# Altium schematic sheet lcd.SchDoc(lcd)
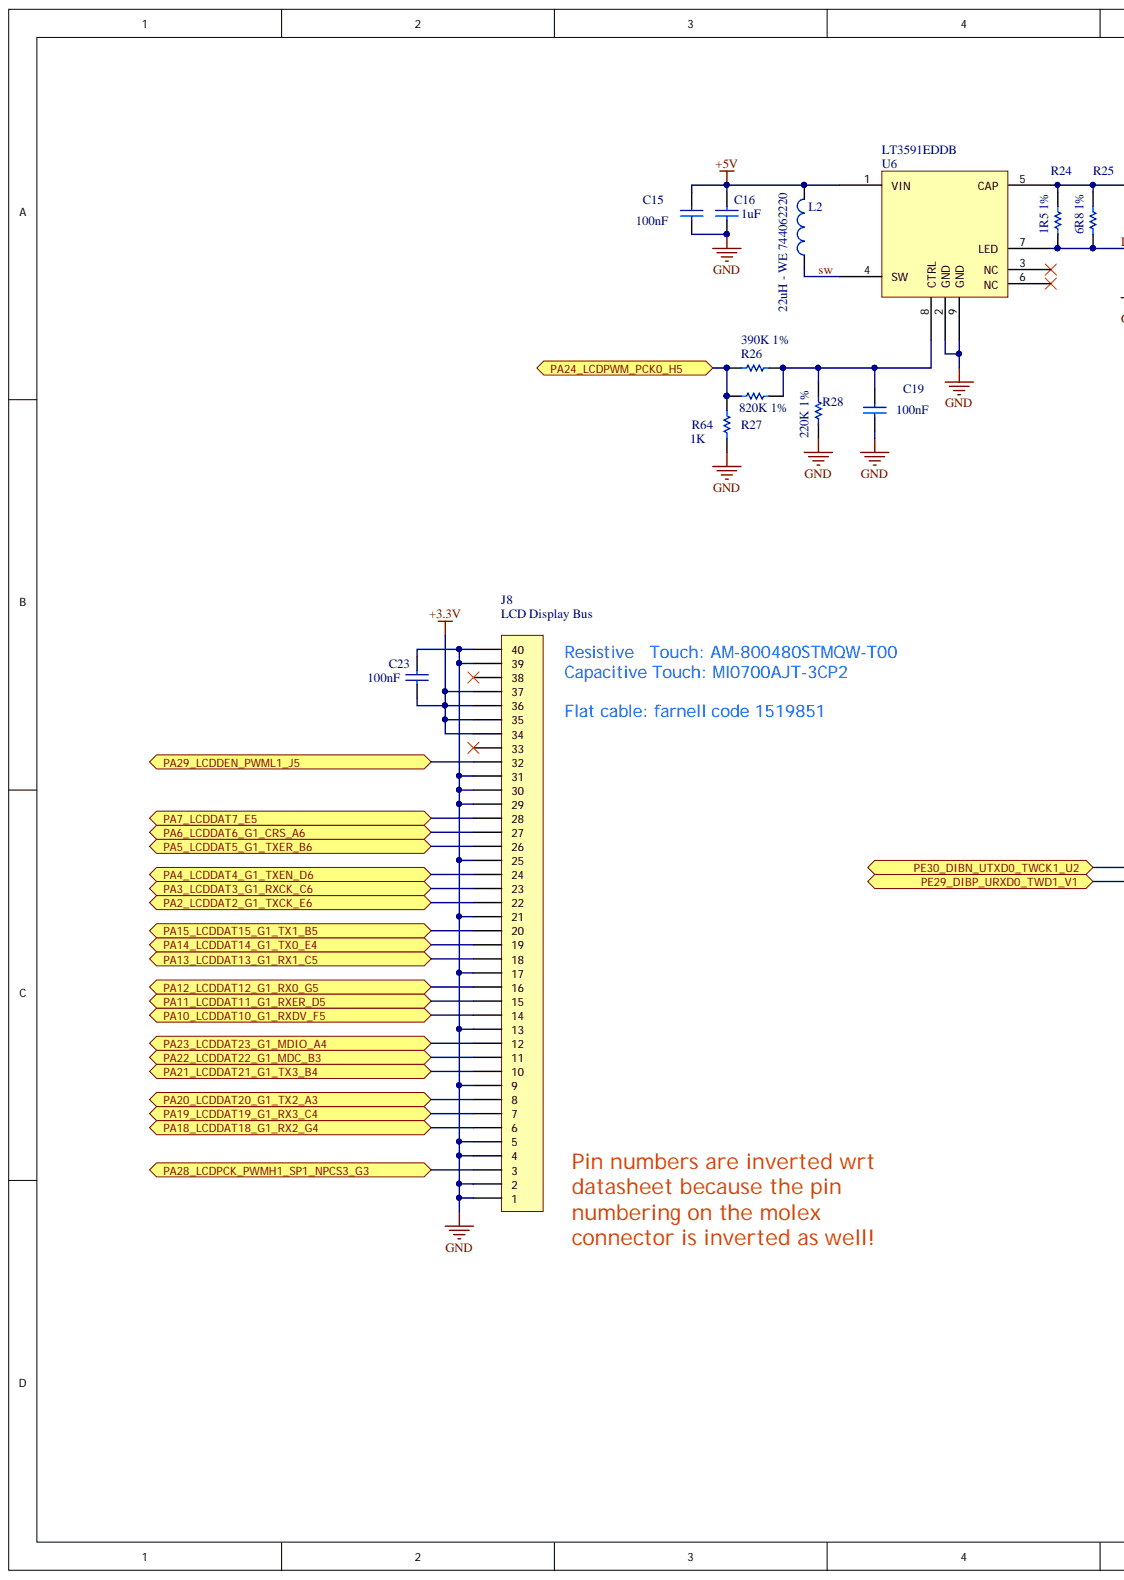
[diagram p0: lcd.SchDoc, left]
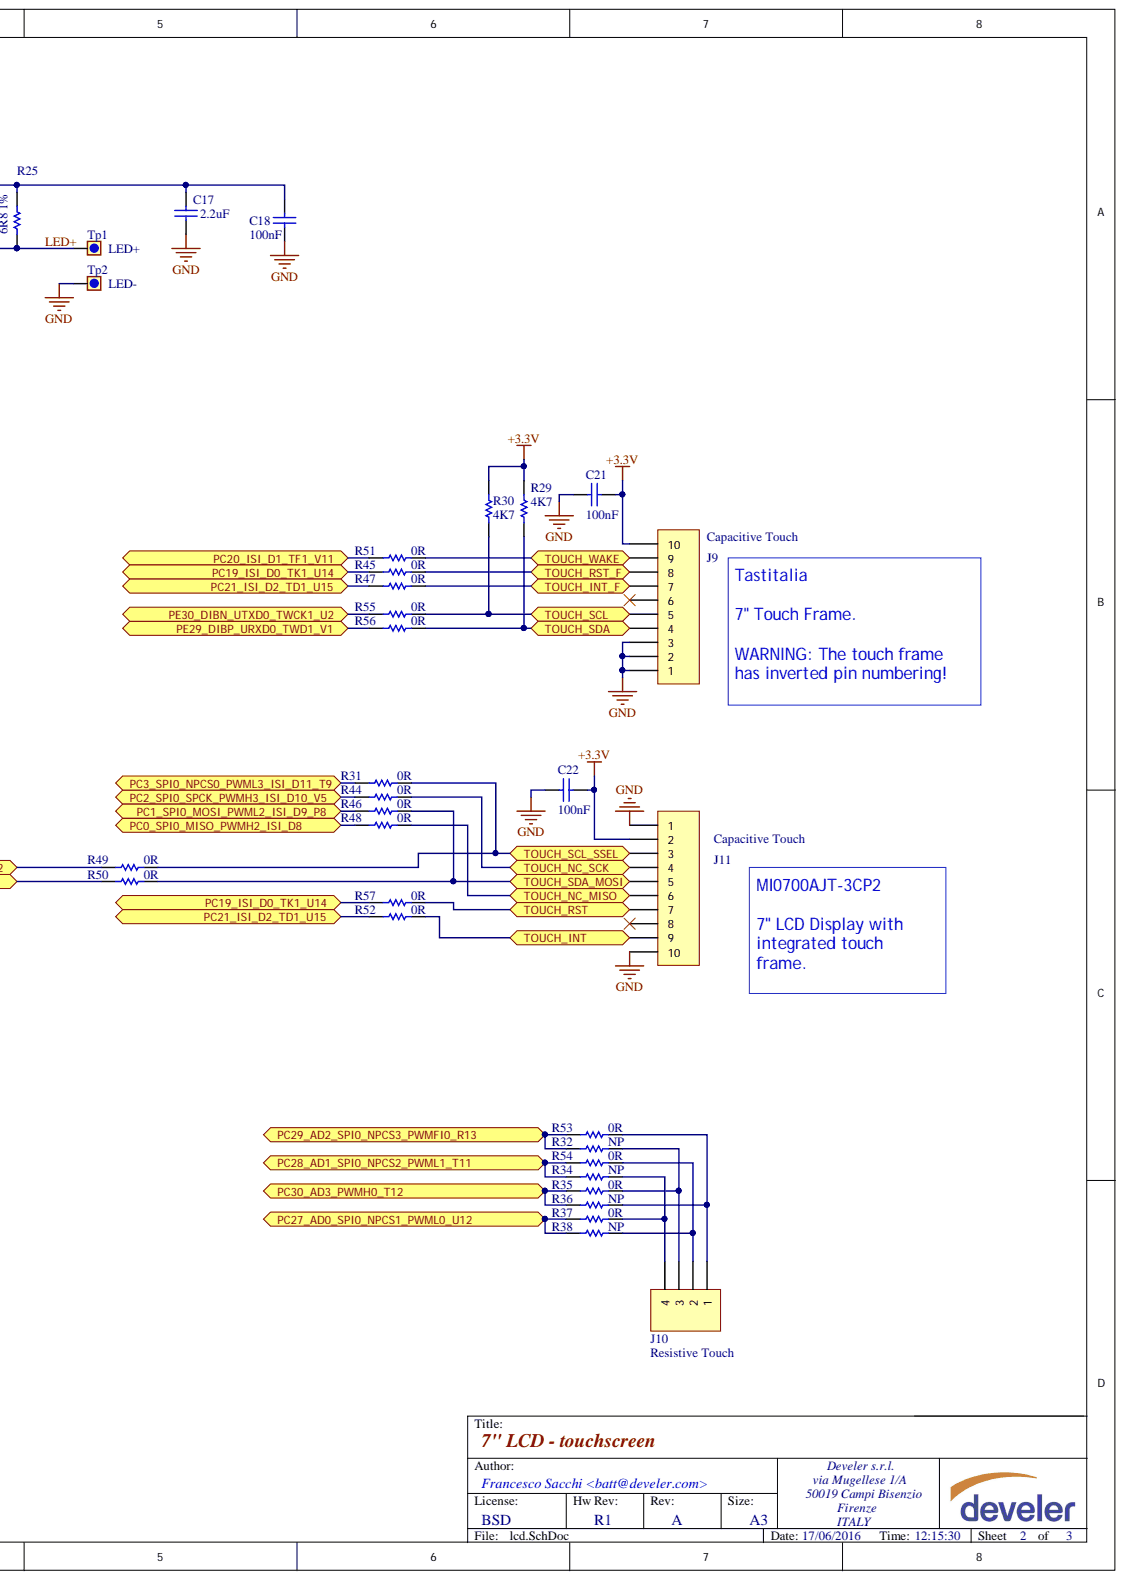
[diagram p1: lcd.SchDoc, right]

## Parts
C15  100nF  [0603_[1608]]
C16  1uF  [0603_[1608]]
C17  2.2uF  [1210_[3225]]
C18  100nF  [0603_[1608]]
C19  100nF  [0603_[1608]]
C21  100nF  [0603_[1608]]
C22  100nF  [0603_[1608]]
C23  100nF  [0603_[1608]]
J8  LCD Display Bus  [fcc-zif_40pin_0.5mm]
J9  Capacitive Touch  [fcc-zif_10pin_0.5mm]
J10  Resistive Touch  [fcc-zif_4pin_1mm]
J11  Capacitive Touch  [fcc-zif_10pin_0.5mm]
L2  22uH - WE 744062220  [WE-TPC-6823-X]
R24  1R5 1%  [0603_[1608]]
R25  6R8 1%  [0603_[1608]]
R26  390K 1%  [0603_[1608]]
R27  820K 1%  [0603_[1608]]
R28  220K 1%  [0603_[1608]]
R29  4K7  [0603_[1608]]
R30  4K7  [0603_[1608]]
R31  0R  [0603_[1608]]
R32  NP  [0603_[1608]]
R34  NP  [0603_[1608]]
R35  0R  [0603_[1608]]
R36  NP  [0603_[1608]]
R37  0R  [0603_[1608]]
R38  NP  [0603_[1608]]
R44  0R  [0603_[1608]]
R45  0R  [0603_[1608]]
R46  0R  [0603_[1608]]
R47  0R  [0603_[1608]]
R48  0R  [0603_[1608]]
R49  0R  [0603_[1608]]
R50  0R  [0603_[1608]]
R51  0R  [0603_[1608]]
R52  0R  [0603_[1608]]
R53  0R  [0603_[1608]]
R54  0R  [0603_[1608]]
R55  0R  [0603_[1608]]
R56  0R  [0603_[1608]]
R57  0R  [0603_[1608]]
R64  1K  [0603_[1608]]
Tp1  LED+  [TEST_POINT_SMD_R5x3MM]  (no BOM)
Tp2  LED-  [TEST_POINT_SMD_R5x3MM]  (no BOM)
U6  LT3591EDDB  [PQFN50P300X200X75_HS-9L]

## Ports
PA2_LCDDAT2_G1_TXCK_E6, PA3_LCDDAT3_G1_RXCK_C6, PA4_LCDDAT4_G1_TXEN_D6, PA5_LCDDAT5_G1_TXER_B6, PA6_LCDDAT6_G1_CRS_A6, PA7_LCDDAT7_E5, PA10_LCDDAT10_G1_RXDV_F5, PA11_LCDDAT11_G1_RXER_D5, PA12_LCDDAT12_G1_RX0_G5, PA13_LCDDAT13_G1_RX1_C5, PA14_LCDDAT14_G1_TX0_E4, PA15_LCDDAT15_G1_TX1_B5, PA18_LCDDAT18_G1_RX2_G4, PA19_LCDDAT19_G1_RX3_C4, PA20_LCDDAT20_G1_TX2_A3, PA21_LCDDAT21_G1_TX3_B4, PA22_LCDDAT22_G1_MDC_B3, PA23_LCDDAT23_G1_MDIO_A4, PA24_LCDPWM_PCK0_H5, PA28_LCDPCK_PWMH1_SP1_NPCS3_G3, PA29_LCDDEN_PWML1_J5, PC0_SPI0_MISO_PWMH2_ISI_D8, PC1_SPI0_MOSI_PWML2_ISI_D9_P8, PC2_SPI0_SPCK_PWMH3_ISI_D10_V5, PC3_SPI0_NPCS0_PWML3_ISI_D11_T9, PC19_ISI_D0_TK1_U14, PC20_ISI_D1_TF1_V11, PC21_ISI_D2_TD1_U15, PC27_AD0_SPI0_NPCS1_PWML0_U12, PC28_AD1_SPI0_NPCS2_PWML1_T11, PC29_AD2_SPI0_NPCS3_PWMFI0_R13, PC30_AD3_PWMH0_T12, PE29_DIBP_URXD0_TWD1_V1, PE30_DIBN_UTXD0_TWCK1_U2, TOUCH_INT, TOUCH_INT_F, TOUCH_NC_MISO, TOUCH_NC_SCK, TOUCH_RST, TOUCH_RST_F, TOUCH_SCL, TOUCH_SCL_SSEL, TOUCH_SDA, TOUCH_SDA_MOSI, TOUCH_WAKE

## Nets
+3.3V: C21.1 C22.1 C23.1 J8.34 J8.35 J8.36 J8.37 J9.10 J11.2 R29.1 R30.1
+5V: C15.2 C16.2 L2.2 U6.1(VIN)
GND: C15.1 C16.1 C17.1 C18.1 C19.1 C21.2 C22.2 C23.2 J8.1 J8.2 J8.4 J8.5 J8.9 J8.13 J8.17 J8.21 J8.25 J8.29 J8.30 J8.31 J8.39 J8.40 J9.1 J9.2 J9.3 J11.1 J11.10 R28.2 R64.2 Tp2.1 U6.2(GND) U6.9(GND)
LED+: R24.1 R25.1 Tp1.1 U6.7(LED)
PA2_LCDDAT2_G1_TXCK_E6: J8.22 ; port PA2_LCDDAT2_G1_TXCK_E6
PA3_LCDDAT3_G1_RXCK_C6: J8.23 ; port PA3_LCDDAT3_G1_RXCK_C6
PA4_LCDDAT4_G1_TXEN_D6: J8.24 ; port PA4_LCDDAT4_G1_TXEN_D6
PA5_LCDDAT5_G1_TXER_B6: J8.26 ; port PA5_LCDDAT5_G1_TXER_B6
PA6_LCDDAT6_G1_CRS_A6: J8.27 ; port PA6_LCDDAT6_G1_CRS_A6
PA7_LCDDAT7_E5: J8.28 ; port PA7_LCDDAT7_E5
PA10_LCDDAT10_G1_RXDV_F5: J8.14 ; port PA10_LCDDAT10_G1_RXDV_F5
PA11_LCDDAT11_G1_RXER_D5: J8.15 ; port PA11_LCDDAT11_G1_RXER_D5
PA12_LCDDAT12_G1_RX0_G5: J8.16 ; port PA12_LCDDAT12_G1_RX0_G5
PA13_LCDDAT13_G1_RX1_C5: J8.18 ; port PA13_LCDDAT13_G1_RX1_C5
PA14_LCDDAT14_G1_TX0_E4: J8.19 ; port PA14_LCDDAT14_G1_TX0_E4
PA15_LCDDAT15_G1_TX1_B5: J8.20 ; port PA15_LCDDAT15_G1_TX1_B5
PA18_LCDDAT18_G1_RX2_G4: J8.6 ; port PA18_LCDDAT18_G1_RX2_G4
PA19_LCDDAT19_G1_RX3_C4: J8.7 ; port PA19_LCDDAT19_G1_RX3_C4
PA20_LCDDAT20_G1_TX2_A3: J8.8 ; port PA20_LCDDAT20_G1_TX2_A3
PA21_LCDDAT21_G1_TX3_B4: J8.10 ; port PA21_LCDDAT21_G1_TX3_B4
PA22_LCDDAT22_G1_MDC_B3: J8.11 ; port PA22_LCDDAT22_G1_MDC_B3
PA23_LCDDAT23_G1_MDIO_A4: J8.12 ; port PA23_LCDDAT23_G1_MDIO_A4
PA24_LCDPWM_PCK0_H5: R26.2 R27.1 R64.1 ; port PA24_LCDPWM_PCK0_H5
PA28_LCDPCK_PWMH1_SP1_NPCS3_G3: J8.3 ; port PA28_LCDPCK_PWMH1_SP1_NPCS3_G3
PA29_LCDDEN_PWML1_J5: J8.32 ; port PA29_LCDDEN_PWML1_J5
PC0_SPI0_MISO_PWMH2_ISI_D8: R48.2 ; port PC0_SPI0_MISO_PWMH2_ISI_D8
PC1_SPI0_MOSI_PWML2_ISI_D9_P8: R46.2 ; port PC1_SPI0_MOSI_PWML2_ISI_D9_P8
PC2_SPI0_SPCK_PWMH3_ISI_D10_V5: R44.2 ; port PC2_SPI0_SPCK_PWMH3_ISI_D10_V5
PC3_SPI0_NPCS0_PWML3_ISI_D11_T9: R31.2 ; port PC3_SPI0_NPCS0_PWML3_ISI_D11_T9
PC19_ISI_D0_TK1_U14: R45.2 R57.2 ; port PC19_ISI_D0_TK1_U14
PC20_ISI_D1_TF1_V11: R51.2 ; port PC20_ISI_D1_TF1_V11
PC21_ISI_D2_TD1_U15: R47.2 R52.2 ; port PC21_ISI_D2_TD1_U15
PC27_AD0_SPI0_NPCS1_PWML0_U12: R37.2 R38.2 ; port PC27_AD0_SPI0_NPCS1_PWML0_U12
PC28_AD1_SPI0_NPCS2_PWML1_T11: R34.2 R54.2 ; port PC28_AD1_SPI0_NPCS2_PWML1_T11
PC29_AD2_SPI0_NPCS3_PWMFI0_R13: R32.2 R53.2 ; port PC29_AD2_SPI0_NPCS3_PWMFI0_R13
PC30_AD3_PWMH0_T12: R35.2 R36.2 ; port PC30_AD3_PWMH0_T12
PE29_DIBP_URXD0_TWD1_V1: R50.2 R56.2 ; port PE29_DIBP_URXD0_TWD1_V1
PE30_DIBN_UTXD0_TWCK1_U2: R49.2 R55.2 ; port PE30_DIBN_UTXD0_TWCK1_U2
SW: L2.1 U6.4(SW)
TOUCH_INT: J11.9 R52.1 ; port TOUCH_INT
TOUCH_INT_F: J9.7 R47.1 ; port TOUCH_INT_F
TOUCH_NC_MISO: J11.6 R48.1 ; port TOUCH_NC_MISO
TOUCH_NC_SCK: J11.4 R44.1 ; port TOUCH_NC_SCK
TOUCH_RST: J11.7 R57.1 ; port TOUCH_RST
TOUCH_RST_F: J9.8 R45.1 ; port TOUCH_RST_F
TOUCH_SCL: J9.5 R30.2 R55.1 ; port TOUCH_SCL
TOUCH_SCL_SSEL: J11.3 R31.1 R49.1 ; port TOUCH_SCL_SSEL
TOUCH_SDA: J9.4 R29.2 R56.1 ; port TOUCH_SDA
TOUCH_SDA_MOSI: J11.5 R46.1 R50.1 ; port TOUCH_SDA_MOSI
TOUCH_WAKE: J9.9 R51.1 ; port TOUCH_WAKE
NetC17_2: C17.2 C18.2 R24.2 R25.2 U6.5(CAP)
NetC19_2: C19.2 R26.1 R27.2 R28.1 U6.8(CTRL)
NetJ10_1: J10.1 R36.1 R53.1
NetJ10_2: J10.2 R38.1 R54.1
NetJ10_3: J10.3 R32.1 R35.1
NetJ10_4: J10.4 R34.1 R37.1
N00233:

Not connected: J8 33 38; J9 6; J11 8; U6 3(NC) 6(NC)

## Library data of the parts
C15 C18 C19 C21-C23 = Cap: Ceramic X7R 50V; Value: 10nF; Component Kind: Standard; Published: 8-Jun-2000; Revision: July-2002: Re-released for DXP Platform.; Signal Integrity: Cap; Simulation: CAP; Library Name: DEVELER_Library.SchLib; Publisher: Altium Limited
C16 = Cap: Ceramic X7R, X5R 16V; Value: 10nF; Component Kind: Standard; Published: 8-Jun-2000; Revision: July-2002: Re-released for DXP Platform.; Signal Integrity: Cap; Simulation: CAP; Library Name: DEVELER_Library.SchLib; Publisher: Altium Limited
C17 = Cap: Ceramic X7R, X5R 50V; Value: 10nF; Component Kind: Standard; Published: 8-Jun-2000; Revision: July-2002: Re-released for DXP Platform.; Signal Integrity: Cap; Simulation: CAP; Library Name: DEVELER_Library.SchLib; Publisher: Altium Limited
J8 = Header 40: 40-pin, 0.5mm, SMT, ZIF, Horizontal, Top Contact (WE 68714014022; Molex: 54104-4031); Component Kind: Standard; LatestRevisionDate: 17-Jul-2002; LatestRevisionNote: Re-released for DXP Platform.; Signal Integrity: Connector; Library Name: DEVELER_Library.SchLib; Publisher: Altium Limited
J9 = Header 10: 10-pin, 0.5mm, SMT, ZIF, Horizontal, Bottom Contact (Molex 52746-1071; WE 68711014522); Component Kind: Standard; LatestRevisionDate: 17-Jul-2002; LatestRevisionNote: Re-released for DXP Platform.; Library Name: Miscellaneous Connectors.IntLib; Signal Integrity: Connector; Publisher: Altium Limited
J10 = Header 4: 4-pin, 0.5mm, SMT, ZIF, Horizontal, Top Contact (Molex 52207-0433; WE 68610414122); Component Kind: Standard; LatestRevisionDate: 17-Jul-2002; LatestRevisionNote: Re-released for DXP Platform.; Library Name: Miscellaneous Connectors.IntLib; Signal Integrity: Connector; Publisher: Altium Limited
J11 = Header 10: 10-pin, 0.5mm, SMT, ZIF, Horizontal, TOP Contact (WE 68711014022; DA VERIFICARE -->Molex 52745-1033); Component Kind: Standard; LatestRevisionDate: 17-Jul-2002; LatestRevisionNote: Re-released for DXP Platform.; Library Name: Miscellaneous Connectors.IntLib; Signal Integrity: Connector; Publisher: Altium Limited
L2 = Inductor: Power Inductor; Component Kind: Standard; Library Name: DEVELER_Library.SchLib
R24 R25 R29 R30 = Res1: Resistor 0.125W; Value: 1K; Component Kind: Standard; Published: 8-Jun-2000; Revision: July-2002: Re-released for DXP Platform.; Signal Integrity: Res; Simulation: RESISTOR; Library Name: DEVELER_Library.SchLib; Publisher: Altium Limited
R26-R28 R31 R32 R34-R38 R44-R57 = Res1: Resistor; Value: 1K; Component Kind: Standard; Published: 8-Jun-2000; Revision: July-2002: Re-released for DXP Platform.; Signal Integrity: Res; Simulation: RESISTOR; Library Name: DEVELER_Library.SchLib; Publisher: Altium Limited
R64 = Res1: Resistor; Component Kind: Standard; Library Name: project42_lib.SchLib; Published: 8-Jun-2000; Revision: July-2002: Re-released for DXP Platform.; Signal Integrity: Res; Simulation: RESISTOR; Value: 1K; Publisher: Altium Limited
Tp1 Tp2 = Test_point: Library Name: DEVELER_Library.SchLib
U6 = LT3591: White LED Driver with Integrated Schottky; Component Kind: Standard; Library Name: DEVELER_Library.SchLib
